# Altium schematic sheet PowerSupply.SchDoc(PowerSupply)
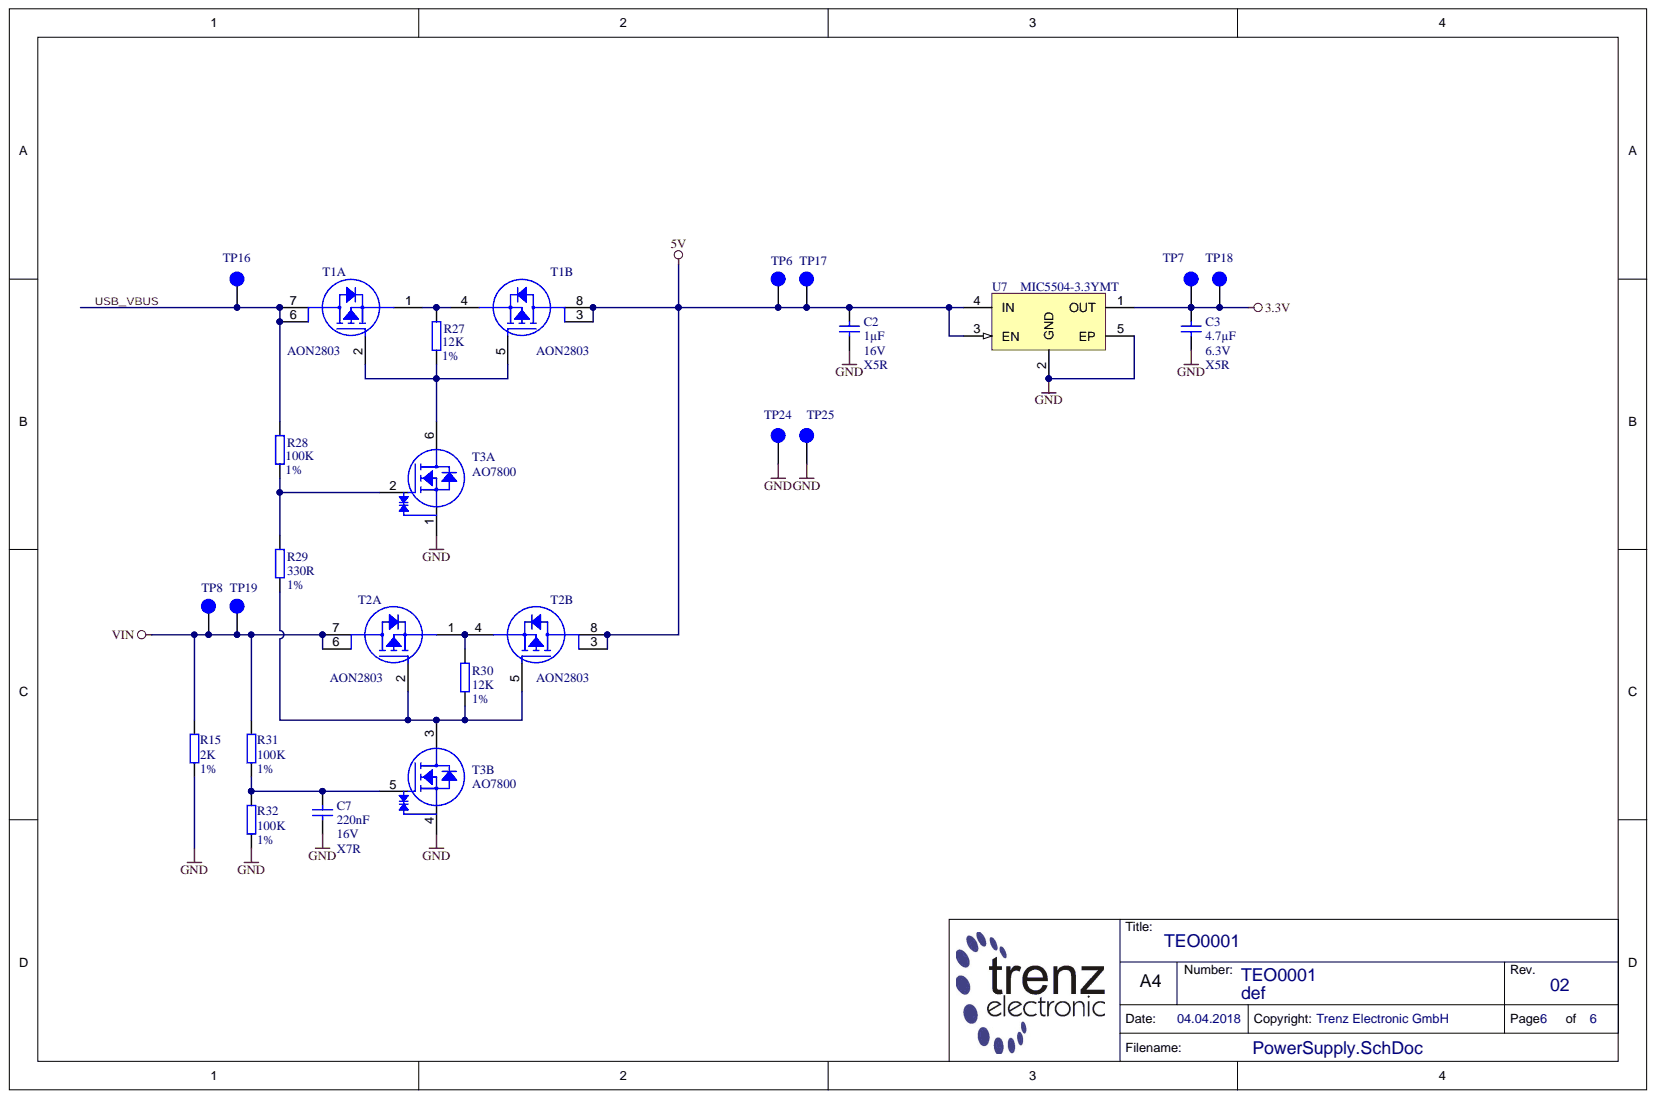
[diagram p0: PowerSupply.SchDoc]

## Parts
C2  1µF  Technology: X5R  Voltage: 16V  [CAPC1005X55N]
C3  4.7µF  Technology: X5R  Voltage: 6.3V  [CAPC1005X60N]
C7  220nF  Technology: X7R  Voltage: 16V  [CAPC1005X55N]
R15  2K  Tolerance: 1%  [RESC1005X40N]
R27  12K  Tolerance: 1%  [RESC1005X40N]
R28  100K  Tolerance: 1%  [RESC1005X40N]
R29  330R  Tolerance: 1%  [RESC1005X40N]
R30  12K  Tolerance: 1%  [RESC1005X40N]
R31  100K  Tolerance: 1%  [RESC1005X40N]
R32  100K  Tolerance: 1%  [RESC1005X40N]
T1  AON2803  [SON65P200X200X80-8T10X06]  (parts A,B)
T2  AON2803  [SON65P200X200X80-8T10X06]  (parts A,B)
T3  AO7800  [SOT65P210X110-6N]  (parts A,B)
TP6  Testpoint 0.8mm  [TP_0.8mm]  (value not drawn)
TP7  Testpoint 0.8mm  [TP_0.8mm]  (value not drawn)
TP8  Testpoint 0.8mm  [TP_0.8mm]  (value not drawn)
TP16  Testpoint 0.8mm  [TP_0.8mm]  (value not drawn)
TP17  Testpoint 0.8mm  [TP_0.8mm]  (value not drawn)
TP18  Testpoint 0.8mm  [TP_0.8mm]  (value not drawn)
TP19  Testpoint 0.8mm  [TP_0.8mm]  (value not drawn)
TP24  Testpoint 0.8mm  [TP_0.8mm]  (value not drawn)
TP25  Testpoint 0.8mm  [TP_0.8mm]  (value not drawn)
U7  MIC5504-3.3YMT  [MIC5504-3.3YMT]

## Nets
3.3V: C3.2 TP7.1 TP18.1 U7.1(OUT)
5V: C2.1 T1.3 T1.8 T2.3 T2.8 TP6.1 TP17.1 U7.3(EN) U7.4(IN)
GND: C2.2 C3.1 C7.1 R15.2 R32.2 T3.1 T3.4 TP24.1 TP25.1 U7.2(GND) U7.5(EP)
VIN: R15.1 R31.1 T2.6 T2.7 TP8.1 TP19.1
USB_VBUS: R28.1 T1.6 T1.7 TP16.1
NetC7_2: C7.2 R31.2 R32.1 T3.5
NetR27_1: R27.1 T1.2 T1.5 T3.6
NetR27_2: R27.2 T1.1 T1.4
NetR28_2: R28.2 R29.2 T3.2
NetR29_1: R29.1 R30.1 T2.2 T2.5 T3.3
NetR30_2: R30.2 T2.1 T2.4

## Library data of the parts
C2 = 26579: KON 1µF 16V X5R SMD 10%; Database Table Name: C_1005_0402; Mixed: SMT; Value: 1u; AN: 26579; Case: 1005 (0402); Component Kind: Standard; Library Name: TE.DbLib; Tolerance: 10%
C3 = 24719: KON 4.7µF 6.3V X5R SMD 20%; Database Table Name: C_1005_0402; Mixed: SMT; Preferred: Yes; Value: 4.7u; AN: 24719; Case: 1005 (0402); Component Kind: Standard; Library Name: TE.DbLib; Tolerance: 20%
C7 = 22768: KON 220nF 16V X7R SMD; Database Table Name: C_1005_0402; Mixed: SMT; Value: 220n; AN: 22768; Case: 1005 (0402); Component Kind: Standard; Library Name: TE.DbLib
R15 = 25073: WID SMD 2K 1% 0,063W; Database Table Name: R_1005_0402; Mixed: SMT; AN: 25073; Case: 1005 (0402); Component Kind: Standard; Library Name: TE.DbLib; Technology: TK100; Value: 2K
R27 R30 = 22598: WID SMD 12K 1% 0,063W; Database Table Name: R_1005_0402; Mixed: SMT; Preferred: Yes; AN: 22598; Case: 1005 (0402); Component Kind: Standard; Library Name: TE.DbLib; Technology: TK100; Value: 12K
R28 R31 R32 = 22558: WID SMD 100K 1% 0,063W; Database Table Name: R_1005_0402; Mixed: SMT; Preferred: Yes; AN: 22558; Case: 1005 (0402); Component Kind: Standard; Library Name: TE.DbLib; Technology: TK100; Value: 100K
R29 = 22762: WID SMD 330R 1% 0,063W; Database Table Name: R_1005_0402; Mixed: SMT; Preferred: Yes; Value: 330; AN: 22762; Case: 1005 (0402); Component Kind: Standard; Library Name: TE.DbLib; Technology: TK100
T1 T2 = 28041: Database Table Name: TRANSISTOR; Mixed: SMT; Value: -3.8A; Voltage: -20V; AN: 28041; Case: DFN6; Component Kind: Standard; Description: AON2803; H-ART: AON2803; Library Name: TE.DbLib; HelpURL: b:\AD\adlib\datasheet\AON2803\
T3 = 28043: Database Table Name: TRANSISTOR; Mixed: SMT; Value: 0.9A; Voltage: 20V; AN: 28043; Case: SOT23-6; Component Kind: Standard; Description: AO7800; H-ART: AO7800; Library Name: TE.DbLib; HelpURL: b:\AD\adlib\datasheet\AO7800\
TP6-TP8 TP16-TP19 TP24 TP25 = 26289: Database Table Name: PCB; Mixed: MECH; AN: 26289; Component Kind: Standard; Description: Testpoint 0.8mm; Library Name: TE.DbLib
U7 = 28312: Database Table Name: LREG; Mixed: SMT; Voltage: 3.3V; AN: 28312; Case: UDFN4; Component Kind: Standard; Description: MIC5504-3.3YMT; H-ART: MIC5504-3.3YMT; Library Name: TE.DbLib; HelpURL: b:\AD\adlib\datasheet\MIC550x\
